# Altium schematic sheet Sheet1.SchDoc
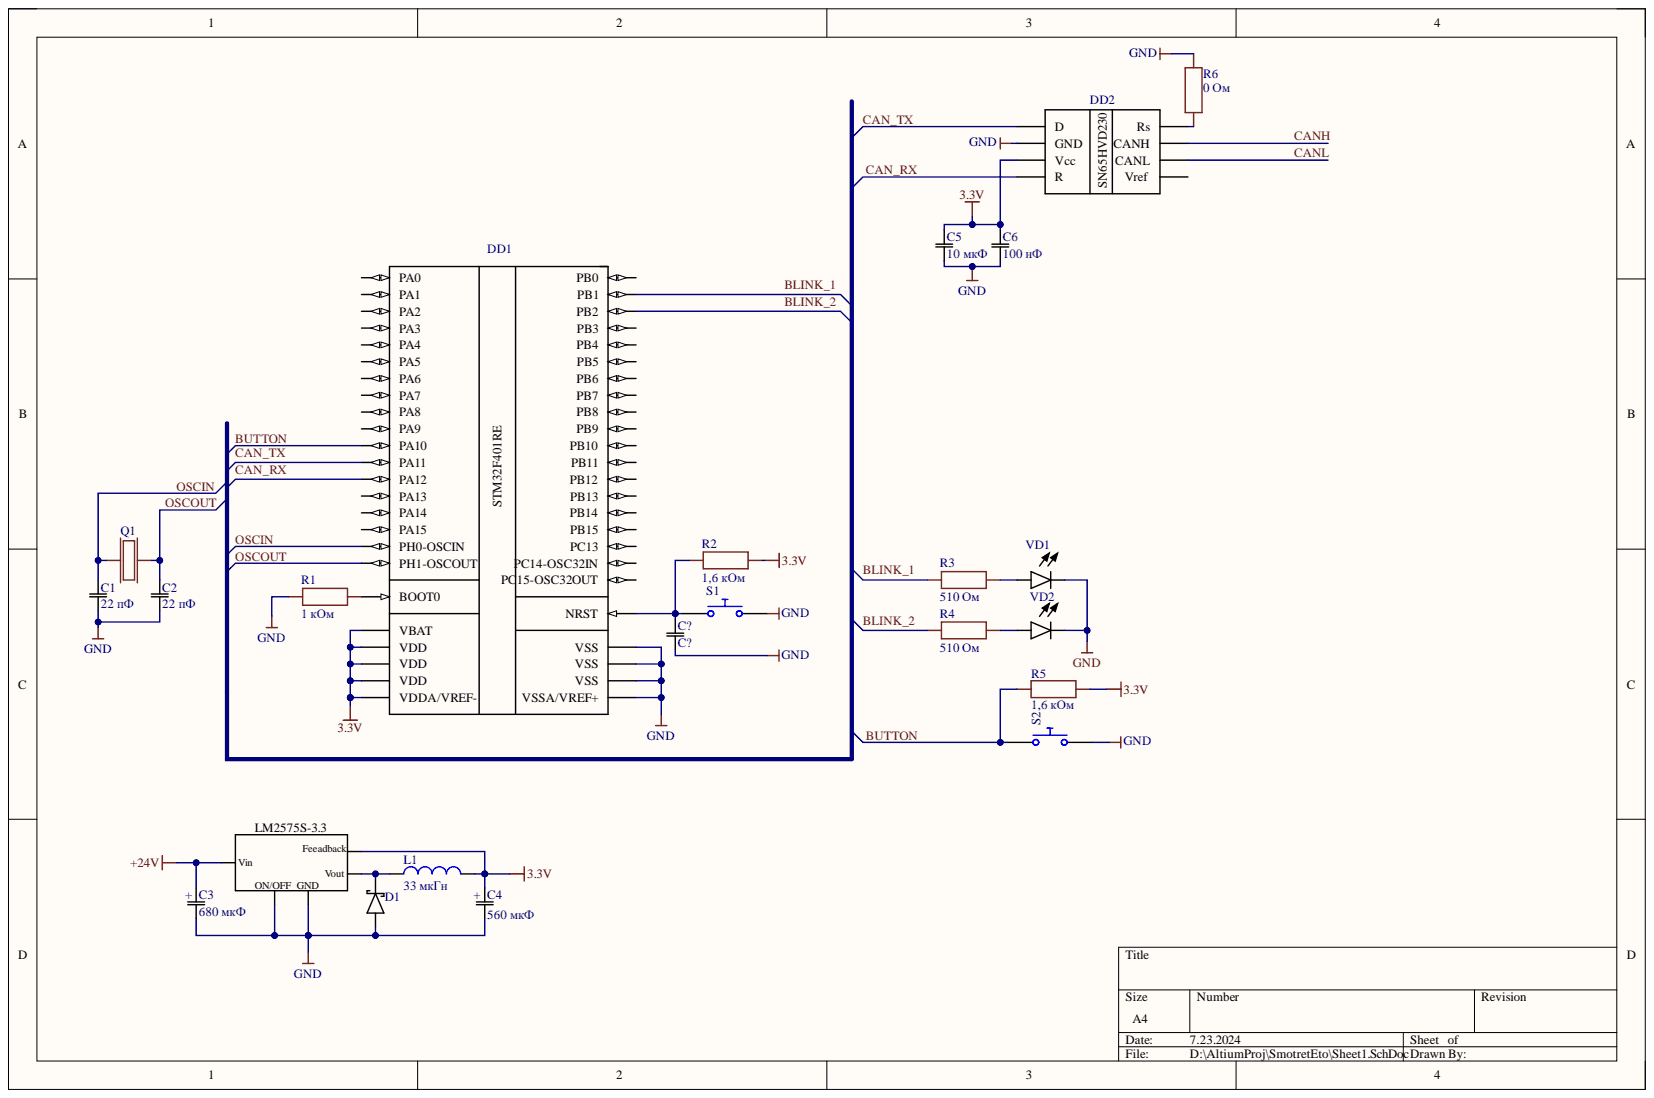
[diagram p0: Sheet1.SchDoc]

## Parts
*  LM2575
C1  22 пФ
C2  22 пФ
C3  680 мкФ
C4  560 мкФ
C5  10 мкФ
C6  100 нФ
C?  C?
D1  Диод Шоттки  (value not drawn)
DD1  STM32F401R  [F401RE]
DD2  SN65HVD230
GND  GND
GND  GND
GND  GND
GND  GND
GND  GND
GND  GND
GND  GND
GND  GND
GND  GND
GND  (no value)
GND  GND
L1  value 33 мкГн  (hidden comment: Inductor)
Q1  Кварц  (value not drawn)
R1  1 кОм
R2  1,6 кОм
R3  510 Ом
R4  510 Ом
R5  1,6 кОм
R6  0 Ом
S1  Push Button Normally Opened  (value not drawn)
S2  Push Button Normally Opened  (value not drawn)
VD1  LED  (value not drawn)
VD2  LED  (value not drawn)

## Nets
3.3V: *. C4.C? C5.C? C6.C? DD1. DD2. L1.2 R2. R5.
+24V: *. C3.C?
Net*: *. C3. C4. D1. GND. L1.1
NetC1: C1. C2. GND.
NetC5: C5. C6. GND.
NetC?: C?. GND.
NetDD1: DD1. GND. R1.
NetDD2: DD2. GND. R6.
NetGND: GND. R1. R6. S1.2 S2.2 VD1. VD2.
NetR3: R3. VD1.
NetR4: R4. VD2.
NetR5: R5. S2.1
NetC1_C?: C1.C? Q1.Q?
NetC2_C?: C2.C? Q1.
NetC?_C?: C?.C? DD1. R2. S1.1

## Library data of the parts
C1-C6 = C?: Component Kind: Standard; Library Name: STM32F401RE.SchLib
DD1 = STM32F401RE: Component Kind: Standard; Library Name: STM32F401RE.SchLib
GND = GND: Component Kind: Standard; Library Name: STM32F401RE.SchLib
L1 = Inductor: SimulationGenericComponent: True; Component Kind: Standard; Description: Inductor; Library Name: Simulation Generic Components.IntLib; Simulation: L_Inductor_Default
R1-R6 = RESISTOR: Component Kind: Standard; Library Name: STM32F401RE.SchLib
S1 S2 = Push Button NO: closed: 0; Rclosed: 1m; Ropen: 1Meg; SimulationGenericComponent: True; Component Kind: Standard; Description: Push Button Normally Opened; Library Name: Simulation Generic Components.IntLib; Simulation: PUSH_BUTTON_NO
VD1 VD2 = LED: LED 5mm; Component Kind: Standard; Library Name: STM32F401RE.SchLib
* = LM2575: Component Kind: Standard; Library Name: STM32F401RE.SchLib
C? = C?: Component Kind: Standard; Library Name: STM32F401RE.SchLib
D1 = Диод Шоттки: Component Kind: Standard; Library Name: STM32F401RE.SchLib
DD2 = SN65HVD230: Component Kind: Standard; Library Name: STM32F401RE.SchLib
GND GND GND GND GND GND GND GND GND GND = GND: Component Kind: Standard; Library Name: STM32F401RE.SchLib
Q1 = Кварц: Component Kind: Standard; Library Name: STM32F401RE.SchLib
